# Altium schematic sheet 光度感应模块_1.1.SchDoc(光度感应模块_1.1)
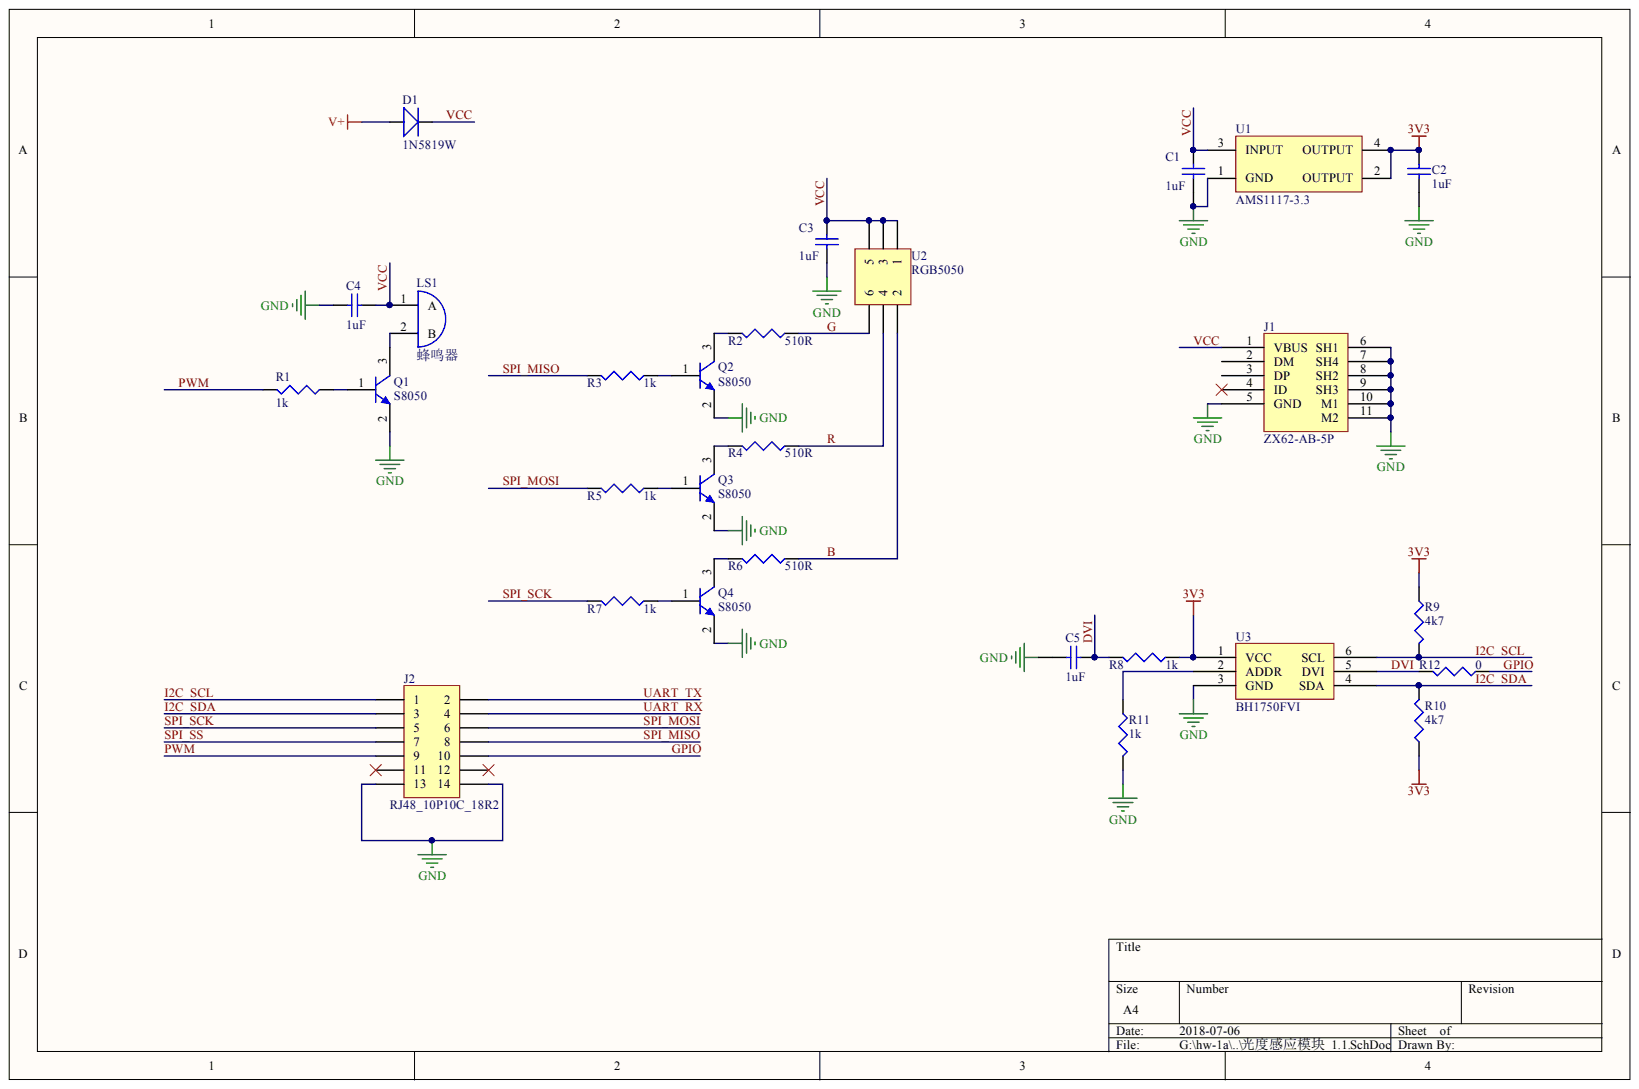
[diagram p0: 光度感应模块_1.1.SchDoc]

## Parts
C1  1uF  [0603-1]
C2  1uF  [0603-1]
C3  1uF  [0603-1]
C4  1uF  [0603-1]
C5  1uF  [0603-1]
D1  1N5819W  [SOD-123]
J1  ZX62-AB-5P  [ZX62D_B_5P8]
J2  RJ48_10P10C_18R2  [RJ48]
LS1  蜂鸣器  [无源蜂鸣器12*6.5]
Q1  S8050  [SOT-23]
Q2  S8050  [SOT-23]
Q3  S8050  [SOT-23]
Q4  S8050  [SOT-23]
R1  1k  [0603-1]
R2  510R  [0603-1]
R3  1k  [0603-1]
R4  510R  [0603-1]
R5  1k  [0603-1]
R6  510R  [0603-1]
R7  1k  [0603-1]
R8  1k  [0603-1]
R9  4k7  [0603-1]
R10  4k7  [0603-1]
R11  1k  [0603-1]
R12  0  [0603-1]
U1  AMS1117-3.3  [SOT223_N]
U2  RGB5050  [RGB]
U3  BH1750FVI  [WSOF6I]

## Nets
3V3: C2.2 R8.1 R9.1 R10.1 U1.2(OUTPUT) U1.4(OUTPUT) U3.1(VCC)
GND: C1.1 C2.1 C3.1 C4.1 C5.1 J1.5(GND) J1.6(SH1) J1.7(SH4) J1.8(SH2) J1.9(SH3) J1.10(M1) J1.11(M2) J2.13 J2.14 Q1.2 Q2.2 Q3.2 Q4.2 R11.2 U1.1(GND) U3.3(GND)
V+: D1.2
B: R6.1 U2.2
DVI: C5.2 R8.2 R12.2 U3.5(DVI)
G: R2.1 U2.6
GPIO: J2.10 R12.1
I2C_SCL: J2.1 R9.2 U3.6(SCL)
I2C_SDA: J2.3 R10.2 U3.4(SDA)
PWM: J2.9 R1.2
R: R4.1 U2.4
SPI_MISO: J2.8 R3.2
SPI_MOSI: J2.6 R5.2
SPI_SCK: J2.5 R7.2
SPI_SS: J2.7
UART_RX: J2.4
UART_TX: J2.2
VCC: C1.2 C3.2 C4.2 D1.1 J1.1(VBUS) LS1.1 U1.3(INPUT) U2.1 U2.3 U2.5
NetLS1_2: LS1.2 Q1.3
NetQ1_1: Q1.1 R1.1
NetQ2_1: Q2.1 R3.1
NetQ2_3: Q2.3 R2.2
NetQ3_1: Q3.1 R5.1
NetQ3_3: Q3.3 R4.2
NetQ4_1: Q4.1 R7.1
NetQ4_3: Q4.3 R6.2
NetR11_1: R11.1 U3.2(ADDR)

Not connected: J1 2(DM) 3(DP) 4(ID); J2 11 12

## Library data of the parts
C1-C5 = Cap Semi: Capacitor (Semiconductor SIM Model); Component Kind: Standard; LatestRevisionDate: 29-May-2009; LatestRevisionNote: IPC-7351 Footprint Added.; Library Name: Miscellaneous Devices.IntLib; PackageDescription: Chip Capacitor; PackageReference: C1206; Published: 8-Jun-2000; Signal Integrity: Cap Semi; Simulation: CAP; Value: 1uF; Publisher: Altium Limited
D1 = 1N5819W: Resistor; Component Kind: Standard; LatestRevisionDate: 29-May-2009; LatestRevisionNote: IPC-7351 Footprint Added.; PackageDescription: Chip Resistor; Published: 8-Jun-2000; Signal Integrity: Res; Simulation: RESISTOR; Value: 1N5819W; Library Name: 温湿度传感器_Project.SCHLIB; Publisher: Altium Limited
J1 = MK5P_4R85_7R2_boundless: Component Kind: Standard; Library Name: STM32F405RGT6.SchLib
J2 = Header 7X2: Header, 7-Pin, Dual row; Component Kind: Standard; LatestRevisionDate: 17-Jul-2002; LatestRevisionNote: Re-released for DXP Platform.; Library Name: Miscellaneous Connectors.IntLib; Signal Integrity: Connector; Publisher: Altium Limited
LS1 = Bell: Electrical Bell; Component Kind: Standard; LatestRevisionDate: 17-Jul-2002; LatestRevisionNote: Re-released for DXP Platform.; Library Name: Miscellaneous Devices.IntLib; PackageReference: PIN2; Published: 24-Mar-1999; Publisher: Altium Limited
Q1-Q4 = SOT-23: NPN General Purpose Amplifier; Component Kind: Standard; ComponentLink1Description: Manufacturer Link; ComponentLink1URL: http://www.fairchildsemi.com/; ComponentLink2Description: Datasheet; ComponentLink2URL: http://www.fairchildsemi.com/ds/2N%2F2N3904.pdf; DatasheetVersion: 1997; LatestRevisionDate: 15-Jan-2003; LatestRevisionNote: PCB Footprint 'BCY-W3/D4.7' replaced; PackageDescription: TO, Flat Index; 3 In-Line, Axial Leads; Body Dia. 4.5mm; Leads 0.51 x 0.48 mm (max); PackageReference: SOT-23; PackageVersion: Sep-1998; Published: 8-Jun-2000; Signal Integrity: 2N3904; Simulation: 2N3904; Library Name: 温湿度传感器_Project.SCHLIB; Publisher: Altium Limited
R1-R12 = Res3: Resistor; Component Kind: Standard; LatestRevisionDate: 29-May-2009; LatestRevisionNote: IPC-7351 Footprint Added.; Library Name: Miscellaneous Devices.IntLib; PackageDescription: Chip Resistor; PackageReference: J1-0603; Published: 8-Jun-2000; Signal Integrity: Res; Simulation: RESISTOR; Publisher: Altium Limited
  R1 R3 R5 R7 R8 R11: Value: 1k
  R2 R4 R6: Value: 510R
  R9 R10: Value: 4k7
  R12: Value: 0
U1 = TLV1117LV33DCY: Component Kind: Standard; Library Name: 1U.SchLib
U2 = Header 3X2: Header, 3-Pin, Dual row; Component Kind: Standard; LatestRevisionDate: 17-Jul-2002; LatestRevisionNote: Re-released for DXP Platform.; Library Name: Miscellaneous Connectors.IntLib; Signal Integrity: Connector; Publisher: Altium Limited
U3 = BH1750FVI: Component Kind: Standard; Library Name: 温湿度传感器_Project.SCHLIB
